# Altium schematic sheet Analog303.SchDoc
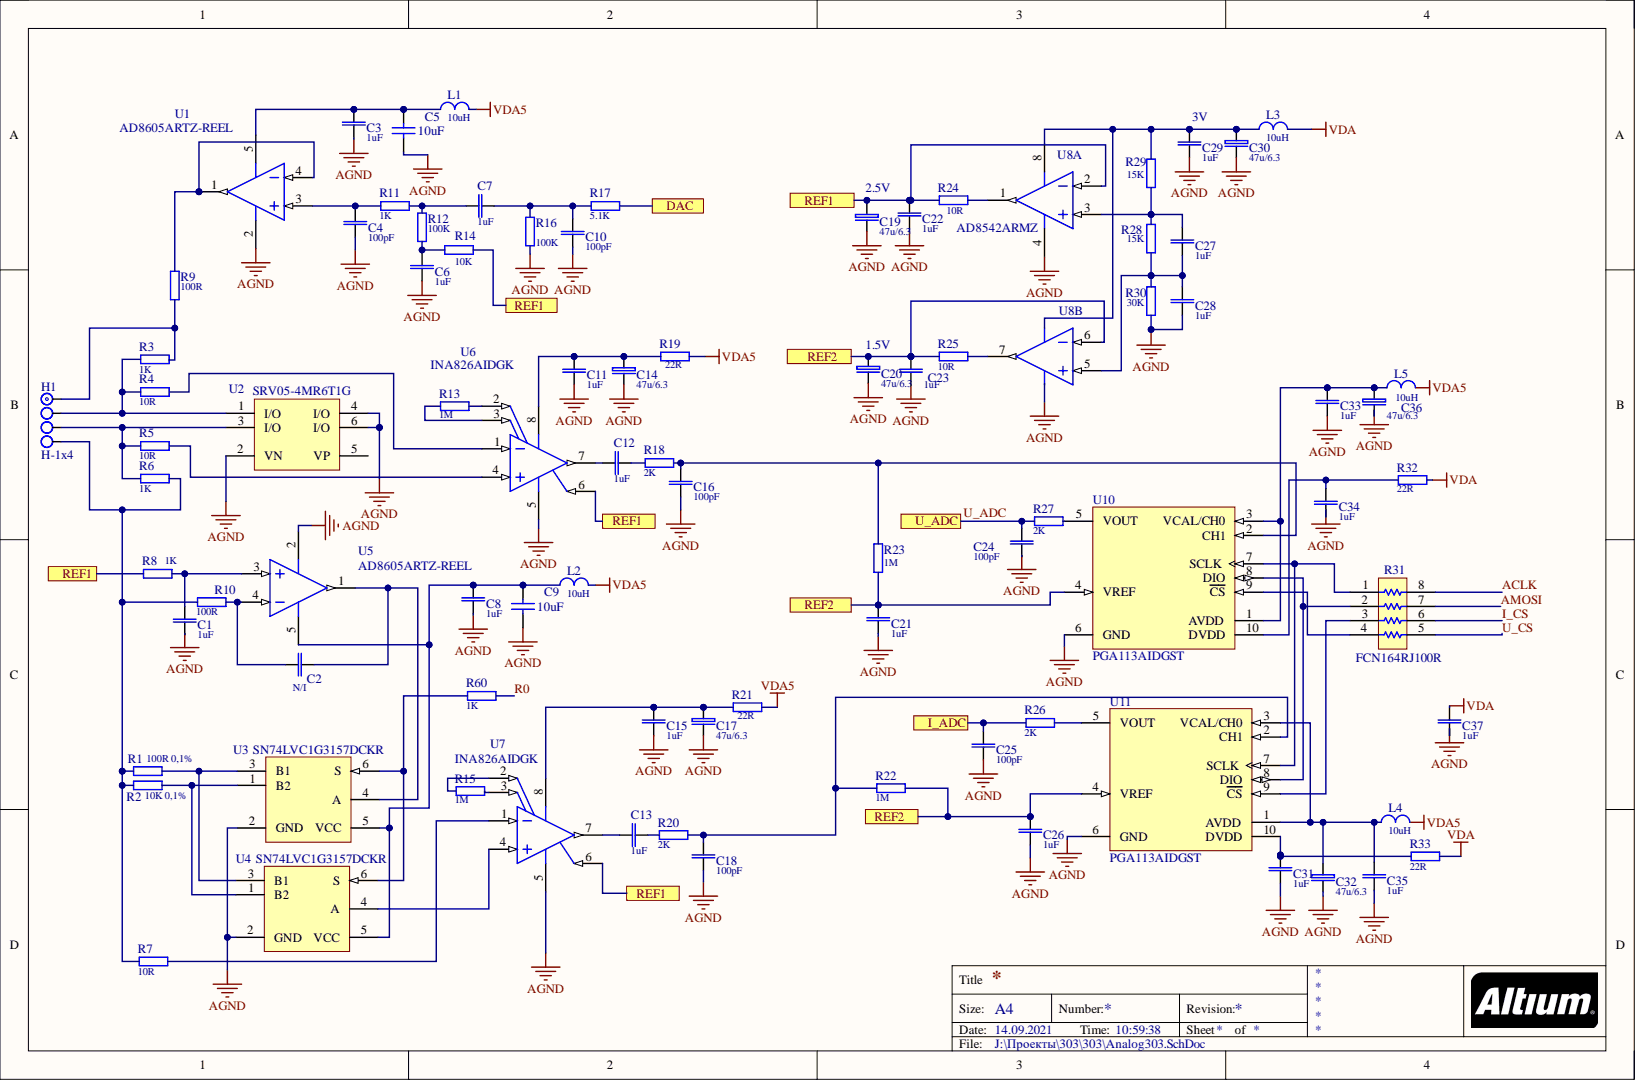
[diagram p0: Analog303.SchDoc]

## Parts
C1  1uF  [CAPC0603]
C2  N/I  [CAPC0603]
C3  1uF  [CAPC0603]
C4  100pF  [CAPC0603]
C5  10uF  [CAPC1206]
C6  1uF  [CAPC0603]
C7  1uF  [CAPC0603]
C8  1uF  [CAPC0603]
C9  10uF  [CAPC1206]
C10  100pF  [CAPC0603]
C11  1uF  [CAPC0603]
C12  1uF  [CAPC0805]
C13  1uF  [CAPC0805]
C14  47u/6.3  [CR2012-0805]
C15  1uF  [CAPC0603]
C16  100pF  [CAPC0603]
C17  47u/6.3  [CR2012-0805]
C18  100pF  [CAPC0603]
C19  47u/6.3  [CR2012-0805]
C20  47u/6.3  [CR2012-0805]
C21  1uF  [CAPC0603]
C22  1uF  [CAPC0603]
C23  1uF  [CAPC0603]
C24  100pF  [CAPC0603]
C25  100pF  [CAPC0603]
C26  1uF  [CAPC0603]
C27  1uF  [CAPC0603]
C28  1uF  [CAPC0603]
C29  1uF  [CAPC0603]
C30  47u/6.3  [CR2012-0805]
C31  1uF  [CAPC0603]
C32  47u/6.3  [CR2012-0805]
C33  1uF  [CAPC0603]
C34  1uF  [CAPC0603]
C35  1uF  [CAPC0603]
C36  47u/6.3  [CR2012-0805]
C37  1uF  [CAPC0603]
H1  H-1x4  [conn]
L1  10uH  [INDC1608X95X40LL15T20]
L2  10uH  [INDC1608X95X40LL15T20]
L3  10uH  [INDC1608X95X40LL15T20]
L4  10uH  [INDC1608X95X40LL15T20]
L5  10uH  [INDC1608X95X40LL15T20]
R1  100R 0,1%  [RESC0603]
R2  10K 0,1%  [RESC0603]
R3  1K  [RESC0603]
R4  10R  [RESC0603]
R5  10R  [RESC0603]
R6  1K  [RESC0603]
R7  10R  [RESC0603]
R8  1K  [RESC0603]
R9  100R  [RESC0603]
R10  100R  [RESC0603]
R11  1K  [RESC0603]
R12  100K  [RESC0603]
R13  1M  [RESC0603]
R14  10K  [RESC0603]
R15  1M  [RESC0603]
R16  100K  [RESC0603]
R17  5.1K  [RESC0603]
R18  2K  [RESC0603]
R19  22R  [RESC0603]
R20  2K  [RESC0603]
R21  22R  [RESC0603]
R22  1M  [RESC0603]
R23  1M  [RESC0603]
R24  10R  [RESC0603]
R25  10R  [RESC0603]
R26  2K  [RESC0603]
R27  2K  [RESC0603]
R28  15K  [RESC0603]
R29  15K  [RESC0603]
R30  30K  [RESC0603]
R31  FCN164RJ100R  [BOUR-CAT16-103J4LF_L]
R32  22R  [RESC0603]
R33  22R  [RESC0603]
R60  1K  [RESC0603]
U1  AD8605ARTZ-REEL  [ADI-RJ-5_N]
U2  SRV05-4MR6T1G  [ONSC-TSOP-6-318G-02_V]
U3  SN74LVC1G3157DCKR  [DCK0006A_M]
U4  SN74LVC1G3157DCKR  [DCK0006A_M]
U5  AD8605ARTZ-REEL  [ADI-RJ-5_N]
U6  INA826AIDGK  [DGK0008A_N]
U7  INA826AIDGK  [DGK0008A_N]
U8  AD8542ARMZ  [ADI-RM-8_N]  (parts A,B)
U10  PGA113AIDGST  [DGS0010A_M]
U11  PGA113AIDGST  [DGS0010A_M]

## Ports
DAC, I_ADC, REF1, REF2, U_ADC

## Nets
AGND: C1.1 C3.1 C4.1 C5.1 C6.1 C8.1 C9.1 C10.1 C11.1 C14.2 C15.1 C16.1 C17.2 C18.1 C19.2 C20.2 C21.1 C22.1 C23.1 C24.1 C25.1 C26.1 C28.1 C29.1 C30.2 C31.1 C32.2 C33.1 C34.1 C35.1 C36.2 C37.1 R16.1 R30.1 U1.2 U2.2(VN) U2.4(I/O) U2.6(I/O) U3.2(GND) U4.2(GND) U5.2 U6.5 U7.5 U8.4 U10.6(GND) U11.6(GND)
VDA: C37.2 L3.2 R32.2 R33.2
VDA5: L1.2 L2.2 L4.2 L5.2 R19.2 R21.2
ACLK: R31.8
AMOSI: R31.7
DAC: R17.2 ; port DAC
I_ADC: C25.2 R26.1 ; port I_ADC
I_CS: R31.6
R0: R60.2
REF1: C19.1 C22.2 R8.1 R14.2 R24.1 U6.6 U7.6 U8.2 ; port REF1
REF2: C20.1 C21.2 C23.2 C26.2 R22.2 R23.1 R25.1 U8.6 U10.4(VREF) U11.4(VREF) ; port REF2
U_ADC: C24.2 R27.1 ; port U_ADC
U_CS: R31.5
NetC1_2: C1.2 R8.2 U5.3
NetC2_1: C2.1 R10.2 U5.4
NetC2_2: C2.2 U3.4(A) U5.1
NetC3_2: C3.2 C5.2 L1.1 U1.5
NetC4_2: C4.2 R11.1 U1.3
NetC6_2: C6.2 R12.1 R14.1
NetC7_1: C7.1 C10.2 R16.2 R17.1
NetC7_2: C7.2 R11.2 R12.2
NetC8_2: C8.2 C9.2 L2.1 U3.5(VCC) U4.5(VCC) U5.5
NetC11_2: C11.2 C14.1 R19.1 U6.8
NetC12_1: C12.1 R18.1
NetC12_2: C12.2 U6.7
NetC13_1: C13.1 R20.1
NetC13_2: C13.2 U7.7
NetC15_2: C15.2 C17.1 R21.1 U7.8
NetC16_2: C16.2 R18.2 R23.2 U10.2(CH1)
NetC18_2: C18.2 R20.2 R22.1 U11.2(CH1)
NetC27_1: C27.1 C28.2 R28.1 R30.2 U8.5
NetC27_2: C27.2 R28.2 R29.1 U8.3
NetC29_2: C29.2 C30.1 L3.1 R29.2 U8.8
NetC31_2: C31.2 R33.1 U11.10(DVDD)
NetC32_1: C32.1 C35.2 L4.1 U11.1(AVDD) U11.3(VCAL/CH0)
NetC33_2: C33.2 C36.1 L5.1 U10.1(AVDD) U10.3(VCAL/CH0)
NetC34_2: C34.2 R32.1 U10.10(DVDD)
NetH1_1: H1.1 R3.2 R9.1
NetH1_2: H1.2 R3.1 R4.1 U2.1(I/O)
NetH1_3: H1.3 R5.1 R6.1 U2.3(I/O)
NetH1_4: H1.4 R1.1 R2.1 R6.2 R7.1 R10.1
NetR1_2: R1.2 U3.3(B1) U4.3(B1)
NetR2_2: R2.2 U3.1(B2) U4.1(B2)
NetR4_2: R4.2 U6.1
NetR5_2: R5.2 U6.4
NetR7_2: R7.2 U7.1
NetR9_2: R9.2 U1.1 U1.4
NetR13_1: R13.1 U6.3
NetR13_2: R13.2 U6.2
NetR15_1: R15.1 U7.2
NetR15_2: R15.2 U7.3
NetR24_2: R24.2 U8.1
NetR25_2: R25.2 U8.7
NetR26_2: R26.2 U11.5(VOUT)
NetR27_2: R27.2 U10.5(VOUT)
NetR31_1: R31.1 U10.7(SCLK) U11.7(SCLK)
NetR31_2: R31.2 U10.8(DIO) U11.8(DIO)
NetR31_3: R31.3 U11.9(CS)
NetR31_4: R31.4 U10.9(CS)
NetR60_1: R60.1 U3.6(S) U4.6(S)
NetU4_4: U4.4(A) U7.4

Not connected: U2 5(VP)

## Library data of the parts
C1 C3 C4 C6-C8 C10 C11 C15 C16 C18 C21-C29 C31 C33-C35 C37 = C-1206: 0603; Component Kind: Standard; Library Name: SMD-RCL.SchLib; Price: 0.014; Signal Integrity: Cap; Simulation: Capacitor
  C1 C3 C6-C8 C11 C15 C21-C23 C26-C29 C31 C33-C35 C37: Value: 1uF
  C4 C10 C16 C18 C24 C25: Value: 100pF
C2 = C-1206: Not install; Component Kind: Standard; Library Name: SMD-RCL.SchLib; Price: 0.014; Signal Integrity: Cap; Simulation: Capacitor; Value: N/I
C5 C9 = CAP: 1206; Component Kind: Standard; Library Name: any
C12 C13 = C-1206: 0805; Component Kind: Standard; Library Name: SMD-RCL.SchLib; Price: 0.014; Signal Integrity: Cap; Simulation: Capacitor; Value: 1uF
C14 C17 C19 C20 C30 C32 C36 = EL Case A (3216-18): Component Kind: Standard; Description: EL Case A (3216-18); Library Name: SMD-RLC.SchLib; Price: 0.014; Signal Integrity: Cap; Simulation: Capacitor; Value: 47u/6.3
H1 = H-1x4: tweezers 2; Component Kind: Standard; Library Name: Connector.SchLib; Price: 0.04
L1-L5 = L2: 0603; TargetPrice: 0.2; Component Kind: Standard; Signal Integrity: L; Simulation: Model Name; Value: 10uH
R1-R30 R32 R33 R60 = R-1206: 0603; Component Kind: Standard; Library Name: SMD-RCL.SchLib; Price: 0.045; Signal Integrity: Res; Simulation: Resistor
  R1: Value: 100R 0,1%
  R2: Value: 10K 0,1%
  R3 R6 R8 R11 R60: Value: 1K
  R4 R5 R7 R24 R25: Value: 10R
  R9 R10: Value: 100R
  R12 R16: Value: 100K
  R13 R15 R22 R23: Value: 1M
  R14: Value: 10K
  R17: Value: 5.1K
  R18 R20 R26 R27: Value: 2K
  R19 R21 R32 R33: Value: 22R
  R28 R29: Value: 15K
  R30: Value: 30K
R31 = CMP-2000-06067-1: Chip Resistor Array,  8-Pin SMD; Manufacturer: Bourns; Circuit Type: Isolated; EDA / CAD Models: DownloadfromAcceleratedDesigns; Number of Resistors: 4; Online Catalog: CAT16Series; Other Names: CAT16-103J4LFDKR; Power Per Element: 62.5mW; Resistance (Ohms): 10k; Temperature Coefficient: ±200ppm/°C; Tolerance: ±5%; Applications: -; Category: Resistors; Component Kind: Standard; Datasheet URL: http://www.mouser.com/ds/2/54/ATCAY-777361.pdf; Datasheet Version: 09/2014; Family: ResistorNetworks,Arrays; Library Name: Altium Content Vault; Manufacturer URL: http://www.bourns.com/; Mounting Technology: SurfaceMount; Mounting Type: SurfaceMount; Number of Pins: 8; Operating Temperature: -55°C~125°C; Package / Case: 1206(3216Metric),Concave,LongSideTerminals; Package Description: 8-Pin Surface Mount Device, Body 3.2 x 1.55 mm, Pitch 0.8 mm; Package Reference: CAT16-103J4LF; Packaging: Digi-Reel®; RoHS Information: CAT16MaterialDeclaration; Series: CAT16; Standard Package: 1; Supplier Device Package: 1206
U1 U5 = CMP-0384-01517-2: Operational Amplifier, SOT23; Generic Part Number: AD8605; Manufacturer: Analog Devices; Amplifiers Per Package: 1; Input Bias Current: 200fA; Input Offset Voltage: 20uV; Iq/Amp: 1.2mA; Slew Rate: 5V/us; Small Signal Bandwidth: 10MHz; V Span Max: 6V; V Span Min: 2.7V; Code_JEDEC: MO-178-AA; Component Kind: Standard; ComponentLink1Description: Manufacturer URL; ComponentLink1URL: http://www.analog.com/en/index.html; ComponentLink2Description: Datasheet; ComponentLink2URL: http://www.analog.com/static/imported-files/data_sheets/AD8605_8606_8608.pdf; ComponentLink3Description: Package Specification; ComponentLink3URL: http://www.analog.com/static/imported-files/packages/PKG_PDF/SOT-23(RJ)/RJ_5.pdf; ComponentLink4Description: Reference Circuit; ComponentLink4URL: http://www.analog.com/en/all-operational-amplifiers-op-amps/operational-amplifiers-op-amps/ad8605/products/product.html#product-recommendations; DatasheetVersion: Rev. L; Library Name: Altium Content Vault; Mounting Technology: Surface Mount; PackageDescription: 5-Pin Small Outline Transistor Package, Body 2.9 x 1.6 mm, Pitch 0.95 mm; PackageReference: RJ-5; PackageVersion: 121608-A; PartNumber: AD8605ARTZ-REEL; RevisionNote: Reference Circuit URL fixed
U2 = CMP-1061-00729-1: Transient Voltage Suppressor,; Manufacturer: On Semiconductor; Direction: Unidirectional; IR Max (uA): 5; Packing: Tape and Reel; PPK Max (W): 300; Component Kind: Standard; ComponentLink1Description: Manufacturer URL; ComponentLink1URL: http://www.onsemi.com/; ComponentLink2Description: Datasheet; ComponentLink2URL: http://www.onsemi.com/pub_link/Collateral/SRV05-4-D.PDF; ComponentLink3Description: Package Specification; ComponentLink3URL: http://www.onsemi.com/pub_link/Collateral/318G-02.PDF; DatasheetVersion: Rev. 1; Library Name: Altium Content Vault; Mounting Technology: Surface Mount; PackageDescription: 6-Pin Small Outline Package, Body 3 x 1.5 mm, Pitch 0.95 mm; PackageReference: TSOP-6-318G-02; PackageVersion: Rev. V, 06/2012; PartNumber: SRV05-4MR6T1G; RoHS: Pb-Free
U3 U4 = CMP-0859-00516-3: analog swich; Manufacturer: Texas Instruments; 3-State Output: No; Bits(#): 1; F @ Nom Voltage(Max)(Mhz): 150; ICC @ Nom Voltage(Max)(mA): 0.01; Input Type: CMOS/TTL; Logic: True; Mux Configuration: 1：2; Output Drive (IOL/IOH)(Max)(mA): 32/-32; Output Type: CMOS; Rating: Catalog; Sub-Family: Analog Switch; Switch Configuration: SPDT; Technology Family: LVC; tpd @ Nom Voltage(Max)(ns): 2,1.2,0.8,0.3; VCC(Max)(V): 5.5; VCC(Min)(V): 1.65; Voltage(Nom)(V): 1.8,2.5,3.3,5; Channels(#): 1; Component Kind: Standard; DatasheetVersion: SCES424H; Generic Part Number: SN74LVC1G3157; Library Name: Altium Content Vault; Operating Temperature Range(C): -40 to 85; PackageReference: DCK0006A; Schmitt Trigger: No
U6 U7 = CMP-0944-00059-2: Instrumental  OP; Manufacturer: Texas Instruments; CMRR(Min)(dB): 84; Eco-Plan: Green (RoHS & no Sb/Br); Gain(V/V): 1 to 1000; Input Bias Current (+/-)(Max)(nA): 65; Input Offset (+/-)(Max)(uV): 150; Input Offset Drift (+/-)(Max)(uV/Degrees Celsius): 2; Noise at 1kHz(Typ)(nV/rt(Hz)): 18; Non-Linearity (+/-)(Max)(%): 0.0005; Number of Channels: 1; Output Offset (+/-)(Max)(uV): 700; Output Offset Drift (+/-)(Max)(uV/Degrees Celsius): 10; Quiescent Current (+/-)(Typ)(mA): 0.2; SubFamily: Single Supply; Vs(Max)(V): 36; Vs(Min)(V): 2.7; Code_JEDEC: MO-187; Component Kind: Standard; ComponentLink1Description: Manufacturer URL; ComponentLink1URL: http://www.ti.com/; ComponentLink2Description: Datasheet; ComponentLink2URL: http://www.ti.com/general/docs/lit/getliterature.tsp?genericPartNumber=INA826&fileType=pdf; ComponentLink3Description: Package Specification; ComponentLink3URL: http://www.ti.com/litv/pdf/mpds028d; DatasheetVersion: SBOS562E; Library Name: Altium Content Vault; Mounting Technology: Surface Mount; PackageDescription: 8-Pin Thin Small Outline, Body 3 x 3 mm, Pitch 0.65 mm; PackageReference: DGK0008A; PackageVersion: 4073329/E, 05/2006; PartNumber: INA826AIDGK
U8 = CMP-0384-01646-1: Operational Amplifier,; Generic Part Number: AD8542; Manufacturer: Analog Devices; Amplifiers Per Package: 2; Input Bias Current: 4pA; Input Offset Voltage: 1mV; Iq/Amp: 65uA; Slew Rate: 920mV/us; Small Signal Bandwidth: 1MHz; V Span Max: 5.5V; V Span Min: 2.7V; Code_JEDEC: MO-187-AA; Component Kind: Standard; ComponentLink1Description: Manufacturer URL; ComponentLink1URL: http://www.analog.com/en/index.html; ComponentLink2Description: Datasheet; ComponentLink2URL: http://www.analog.com/static/imported-files/data_sheets/AD8541_8542_8544.pdf; ComponentLink3Description: Package Specification; ComponentLink3URL: http://www.analog.com/static/imported-files/packages/PKG_PDF/MSOP%28RM%29/RM_8.pdf; DatasheetVersion: Rev. G; Library Name: Altium Content Vault; Mounting Technology: Surface Mount; PackageDescription: 8-Pin Mini Small Outline Package, Body 3 x 3 mm, Pitch 0.65 mm; PackageReference: RM-8; PartId: 1; PartNumber: AD8542ARMZ
U10 U11 = CMP-0988-00045-2: Programmable Gain Amplifier; Manufacturer: Texas Instruments; Common Mode Input High(Min)(V): AVdd -0.1V; Common Mode Input Low(Min)(V): GND + 0.1V; Eco-Plan: Green (RoHS & no Sb/Br); Gain Error (+/-)(Max)(%): 0.1; Gain(V/V): 1, 2, 5, 10, 20, 50, 100, 200; Input Bias Current (+/-)(Max)(nA): 5; Input Offset (+/-)(Max)(uV): 100; Input Offset Drift (+/-)(Max)(uV/Degrees Celsius): 1.2; Noise at 1kHz(Typ)(nV/rt(Hz)): 13; Non-Linearity (+/-)(Max)(%): 0.0015; Number of Channels: 2; Quiescent Current (+/-)(Typ)(mA): 1; Settling Time to 0.01%(Typ)(us): 2.55; Slew Rate(Typ)(V/us): 3; Vs(Max)(V): 5.5; Vs(Min)(V): 2.2; Code_JEDEC: MO-153; Component Kind: Standard; ComponentLink1Description: Manufacturer URL; ComponentLink1URL: http://www.ti.com/; ComponentLink2Description: Datasheet; ComponentLink2URL: http://www.ti.com/general/docs/lit/getliterature.tsp?genericPartNumber=PGA113&fileType=pdf; ComponentLink3Description: Package Specification; ComponentLink3URL: http://www.ti.com/lit/ml/mpds035b/mpds035b.pdf; DatasheetVersion: SBOS424B; Library Name: Altium Content Vault; Mounting Technology: Surface Mount; PackageDescription: 10-Pin Plastic Small Outline, Body 3 x 3 mm, Pitch 0.5 mm; PackageReference: DGS0010A; PackageVersion: 4073272/C, 02/2004; PartNumber: PGA113AIDGST; SubFamily: Programmable Gain Amplifier
